# Altium schematic sheet ICWSHLP2_Adaptor_2x2_FanoutBuffer.SchDoc(ICWSHLP2_Adaptor_2x2_FanoutBuffer)
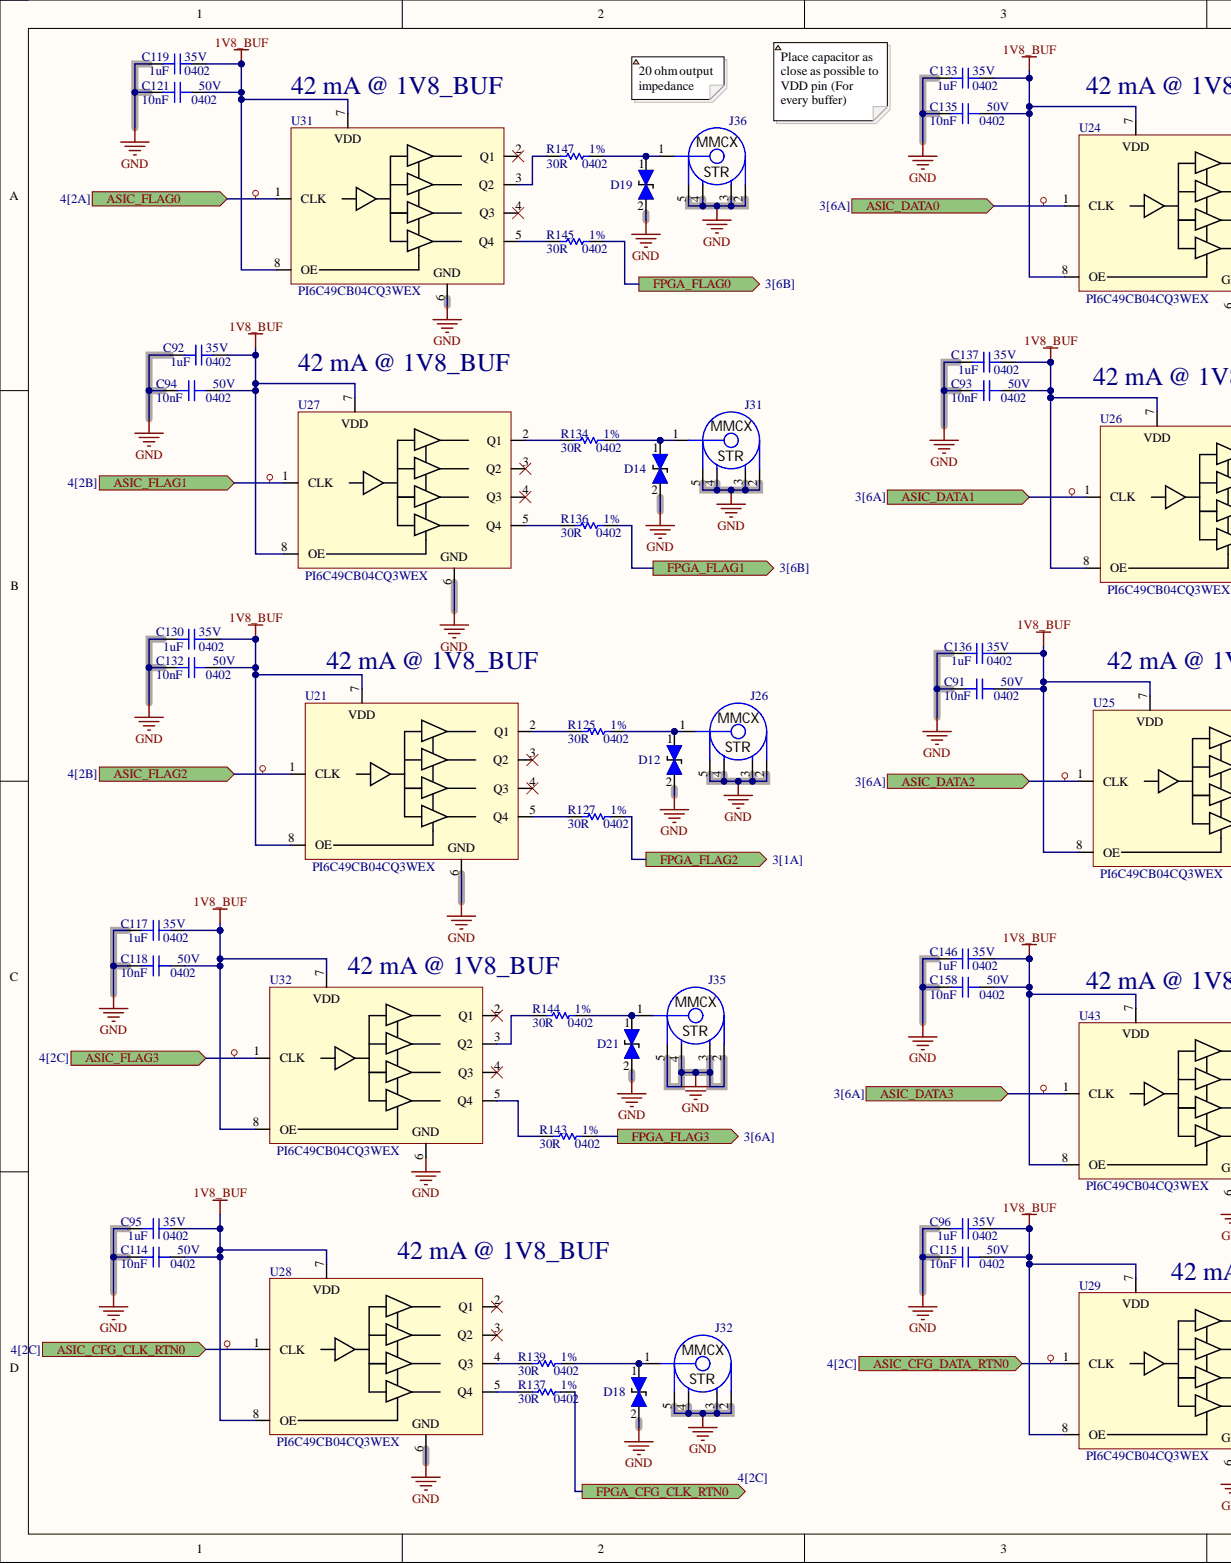
[diagram p0: ICWSHLP2_Adaptor_2x2_FanoutBuffer.SchDoc, left]
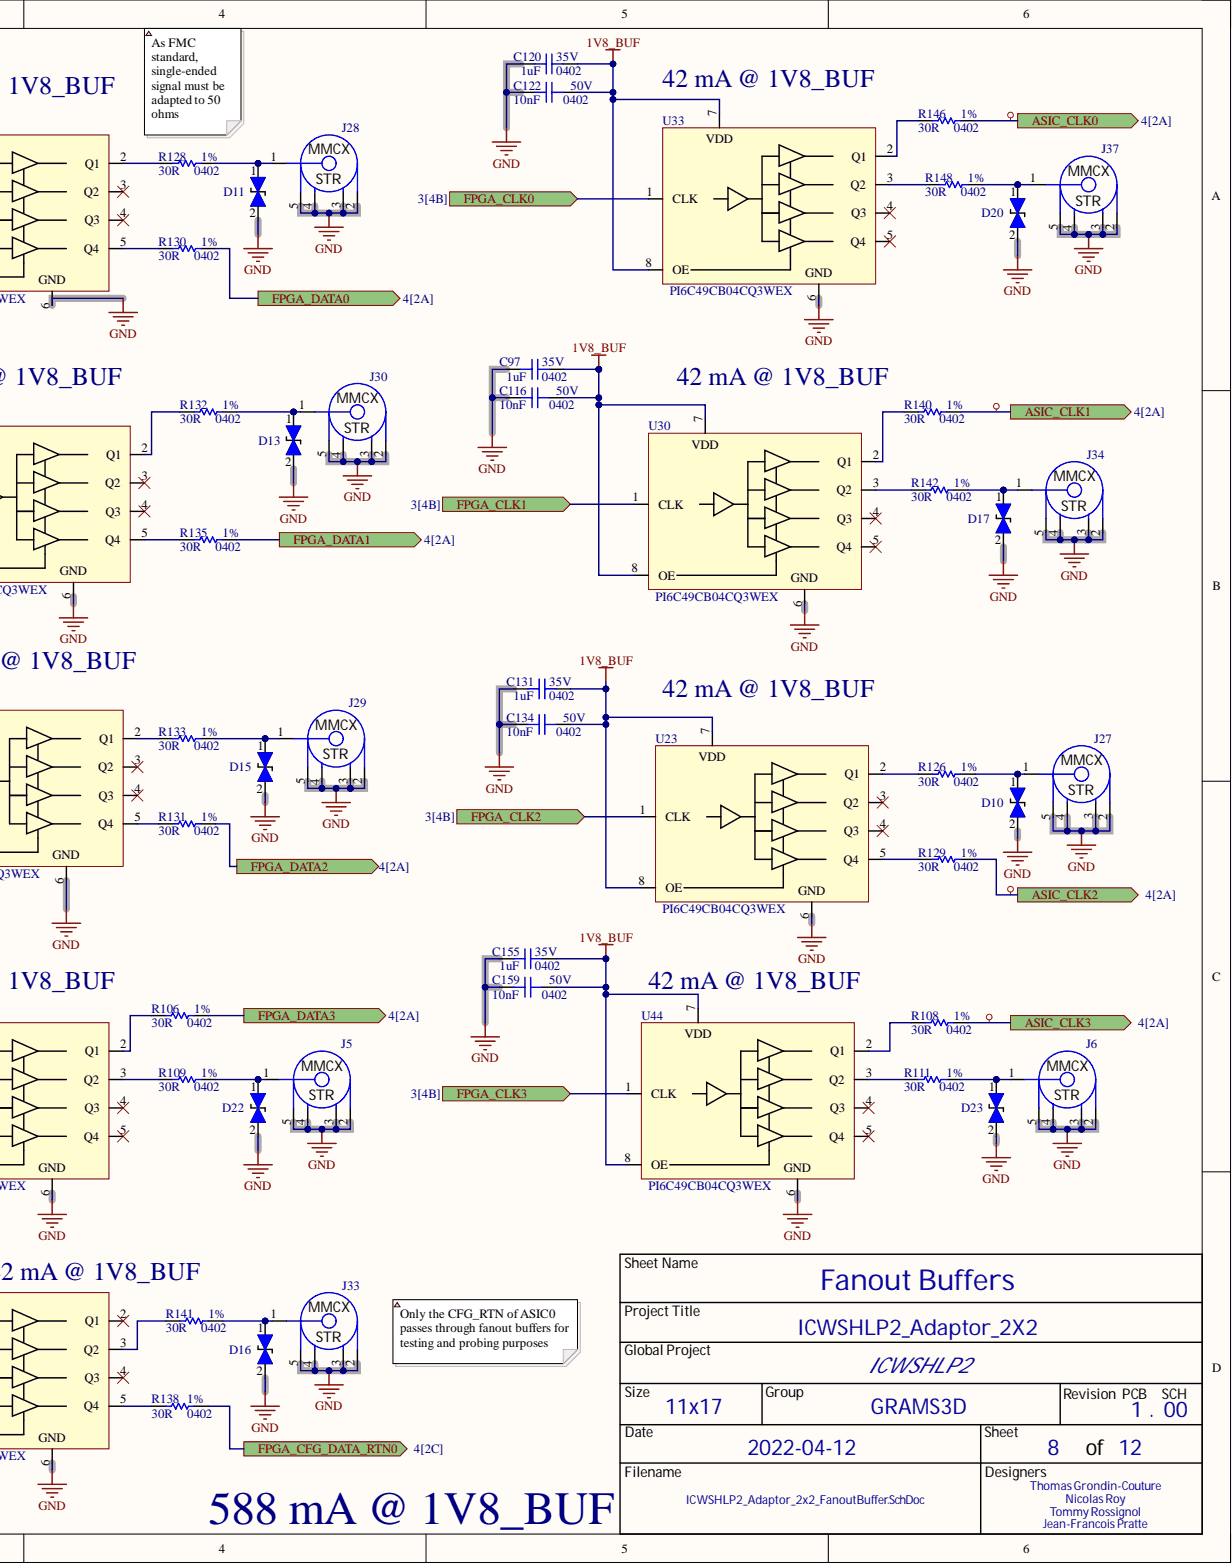
[diagram p1: ICWSHLP2_Adaptor_2x2_FanoutBuffer.SchDoc, right]

## Parts
C91  value 10nF  Package: 0402  Voltage Rating: 50V  [CAP_CER_0402]  (hidden comment: GRM155R71H103KA88D)
C92  value 1uF  Package: 0402  Voltage Rating: 35V  [CAP_CER_0402]  (hidden comment: CL05A105KL5NRNC)
C93  value 10nF  Package: 0402  Voltage Rating: 50V  [CAP_CER_0402]  (hidden comment: GRM155R71H103KA88D)
C94  value 10nF  Package: 0402  Voltage Rating: 50V  [CAP_CER_0402]  (hidden comment: GRM155R71H103KA88D)
C95  value 1uF  Package: 0402  Voltage Rating: 35V  [CAP_CER_0402]  (hidden comment: CL05A105KL5NRNC)
C96  value 1uF  Package: 0402  Voltage Rating: 35V  [CAP_CER_0402]  (hidden comment: CL05A105KL5NRNC)
C97  value 1uF  Package: 0402  Voltage Rating: 35V  [CAP_CER_0402]  (hidden comment: CL05A105KL5NRNC)
C114  value 10nF  Package: 0402  Voltage Rating: 50V  [CAP_CER_0402]  (hidden comment: GRM155R71H103KA88D)
C115  value 10nF  Package: 0402  Voltage Rating: 50V  [CAP_CER_0402]  (hidden comment: GRM155R71H103KA88D)
C116  value 10nF  Package: 0402  Voltage Rating: 50V  [CAP_CER_0402]  (hidden comment: GRM155R71H103KA88D)
C117  value 1uF  Package: 0402  Voltage Rating: 35V  [CAP_CER_0402]  (hidden comment: CL05A105KL5NRNC)
C118  value 10nF  Package: 0402  Voltage Rating: 50V  [CAP_CER_0402]  (hidden comment: GRM155R71H103KA88D)
C119  value 1uF  Package: 0402  Voltage Rating: 35V  [CAP_CER_0402]  (hidden comment: CL05A105KL5NRNC)
C120  value 1uF  Package: 0402  Voltage Rating: 35V  [CAP_CER_0402]  (hidden comment: CL05A105KL5NRNC)
C121  value 10nF  Package: 0402  Voltage Rating: 50V  [CAP_CER_0402]  (hidden comment: GRM155R71H103KA88D)
C122  value 10nF  Package: 0402  Voltage Rating: 50V  [CAP_CER_0402]  (hidden comment: GRM155R71H103KA88D)
C130  value 1uF  Package: 0402  Voltage Rating: 35V  [CAP_CER_0402]  (hidden comment: CL05A105KL5NRNC)
C131  value 1uF  Package: 0402  Voltage Rating: 35V  [CAP_CER_0402]  (hidden comment: CL05A105KL5NRNC)
C132  value 10nF  Package: 0402  Voltage Rating: 50V  [CAP_CER_0402]  (hidden comment: GRM155R71H103KA88D)
C133  value 1uF  Package: 0402  Voltage Rating: 35V  [CAP_CER_0402]  (hidden comment: CL05A105KL5NRNC)
C134  value 10nF  Package: 0402  Voltage Rating: 50V  [CAP_CER_0402]  (hidden comment: GRM155R71H103KA88D)
C135  value 10nF  Package: 0402  Voltage Rating: 50V  [CAP_CER_0402]  (hidden comment: GRM155R71H103KA88D)
C136  value 1uF  Package: 0402  Voltage Rating: 35V  [CAP_CER_0402]  (hidden comment: CL05A105KL5NRNC)
C137  value 1uF  Package: 0402  Voltage Rating: 35V  [CAP_CER_0402]  (hidden comment: CL05A105KL5NRNC)
C146  value 1uF  Package: 0402  Voltage Rating: 35V  [CAP_CER_0402]  (hidden comment: CL05A105KL5NRNC)
C155  value 1uF  Package: 0402  Voltage Rating: 35V  [CAP_CER_0402]  (hidden comment: CL05A105KL5NRNC)
C158  value 10nF  Package: 0402  Voltage Rating: 50V  [CAP_CER_0402]  (hidden comment: GRM155R71H103KA88D)
C159  value 10nF  Package: 0402  Voltage Rating: 50V  [CAP_CER_0402]  (hidden comment: GRM155R71H103KA88D)
D10  D5V0P1B2LP-7B  [TVS_DATA_D5V0P1B2LP-7B]  (value not drawn)
D11  D5V0P1B2LP-7B  [TVS_DATA_D5V0P1B2LP-7B]  (value not drawn)
D12  D5V0P1B2LP-7B  [TVS_DATA_D5V0P1B2LP-7B]  (value not drawn)
D13  D5V0P1B2LP-7B  [TVS_DATA_D5V0P1B2LP-7B]  (value not drawn)
D14  D5V0P1B2LP-7B  [TVS_DATA_D5V0P1B2LP-7B]  (value not drawn)
D15  D5V0P1B2LP-7B  [TVS_DATA_D5V0P1B2LP-7B]  (value not drawn)
D16  D5V0P1B2LP-7B  [TVS_DATA_D5V0P1B2LP-7B]  (value not drawn)
D17  D5V0P1B2LP-7B  [TVS_DATA_D5V0P1B2LP-7B]  (value not drawn)
D18  D5V0P1B2LP-7B  [TVS_DATA_D5V0P1B2LP-7B]  (value not drawn)
D19  D5V0P1B2LP-7B  [TVS_DATA_D5V0P1B2LP-7B]  (value not drawn)
D20  D5V0P1B2LP-7B  [TVS_DATA_D5V0P1B2LP-7B]  (value not drawn)
D21  D5V0P1B2LP-7B  [TVS_DATA_D5V0P1B2LP-7B]  (value not drawn)
D22  D5V0P1B2LP-7B  [TVS_DATA_D5V0P1B2LP-7B]  (value not drawn)
D23  D5V0P1B2LP-7B  [TVS_DATA_D5V0P1B2LP-7B]  (value not drawn)
J5  DATA3  [CON_MMCX_STR_CONMMCX001]
J6  CLK3  [CON_MMCX_STR_CONMMCX001]
J26  FLAG2  [CON_MMCX_STR_CONMMCX001]  (value not drawn)
J27  CLK2  [CON_MMCX_STR_CONMMCX001]  (value not drawn)
J28  DATA0  [CON_MMCX_STR_CONMMCX001]  (value not drawn)
J29  DATA2  [CON_MMCX_STR_CONMMCX001]  (value not drawn)
J30  DATA1  [CON_MMCX_STR_CONMMCX001]  (value not drawn)
J31  FLAG1  [CON_MMCX_STR_CONMMCX001]  (value not drawn)
J32  CLK_RTN0  [CON_MMCX_STR_CONMMCX001]  (value not drawn)
J33  DATA_RTN0  [CON_MMCX_STR_CONMMCX001]
J34  CLK1  [CON_MMCX_STR_CONMMCX001]  (value not drawn)
J35  FLAG3  [CON_MMCX_STR_CONMMCX001]  (value not drawn)
J36  FLAG0  [CON_MMCX_STR_CONMMCX001]  (value not drawn)
J37  CLK0  [CON_MMCX_STR_CONMMCX001]
R106  value 30R  Package: 0402  Tolerance: 1%  [RES_0402]  (hidden comment: RC0402FR-0730RL)
R108  value 30R  Package: 0402  Tolerance: 1%  [RES_0402]  (hidden comment: RC0402FR-0730RL)
R109  value 30R  Package: 0402  Tolerance: 1%  [RES_0402]  (hidden comment: RC0402FR-0730RL)
R111  value 30R  Package: 0402  Tolerance: 1%  [RES_0402]  (hidden comment: RC0402FR-0730RL)
R125  value 30R  Package: 0402  Tolerance: 1%  [RES_0402]  (hidden comment: RC0402FR-0730RL)
R126  value 30R  Package: 0402  Tolerance: 1%  [RES_0402]  (hidden comment: RC0402FR-0730RL)
R127  value 30R  Package: 0402  Tolerance: 1%  [RES_0402]  (hidden comment: RC0402FR-0730RL)
R128  value 30R  Package: 0402  Tolerance: 1%  [RES_0402]  (hidden comment: RC0402FR-0730RL)
R129  value 30R  Package: 0402  Tolerance: 1%  [RES_0402]  (hidden comment: RC0402FR-0730RL)
R130  value 30R  Package: 0402  Tolerance: 1%  [RES_0402]  (hidden comment: RC0402FR-0730RL)
R131  value 30R  Package: 0402  Tolerance: 1%  [RES_0402]  (hidden comment: RC0402FR-0730RL)
R132  value 30R  Package: 0402  Tolerance: 1%  [RES_0402]  (hidden comment: RC0402FR-0730RL)
R133  value 30R  Package: 0402  Tolerance: 1%  [RES_0402]  (hidden comment: RC0402FR-0730RL)
R134  value 30R  Package: 0402  Tolerance: 1%  [RES_0402]  (hidden comment: RC0402FR-0730RL)
R135  value 30R  Package: 0402  Tolerance: 1%  [RES_0402]  (hidden comment: RC0402FR-0730RL)
R136  value 30R  Package: 0402  Tolerance: 1%  [RES_0402]  (hidden comment: RC0402FR-0730RL)
R137  value 30R  Package: 0402  Tolerance: 1%  [RES_0402]  (hidden comment: RC0402FR-0730RL)
R138  value 30R  Package: 0402  Tolerance: 1%  [RES_0402]  (hidden comment: RC0402FR-0730RL)
R139  value 30R  Package: 0402  Tolerance: 1%  [RES_0402]  (hidden comment: RC0402FR-0730RL)
R140  value 30R  Package: 0402  Tolerance: 1%  [RES_0402]  (hidden comment: RC0402FR-0730RL)
R141  value 30R  Package: 0402  Tolerance: 1%  [RES_0402]  (hidden comment: RC0402FR-0730RL)
R142  value 30R  Package: 0402  Tolerance: 1%  [RES_0402]  (hidden comment: RC0402FR-0730RL)
R143  value 30R  Package: 0402  Tolerance: 1%  [RES_0402]  (hidden comment: RC0402FR-0730RL)
R144  value 30R  Package: 0402  Tolerance: 1%  [RES_0402]  (hidden comment: RC0402FR-0730RL)
R145  value 30R  Package: 0402  Tolerance: 1%  [RES_0402]  (hidden comment: RC0402FR-0730RL)
R146  value 30R  Package: 0402  Tolerance: 1%  [RES_0402]  (hidden comment: RC0402FR-0730RL)
R147  value 30R  Package: 0402  Tolerance: 1%  [RES_0402]  (hidden comment: RC0402FR-0730RL)
R148  value 30R  Package: 0402  Tolerance: 1%  [RES_0402]  (hidden comment: RC0402FR-0730RL)
U21  PI6C49CB04CQ3WEX  [TIM_FAN_PI6C49CB04CQ3WEX]  (value not drawn)
U23  PI6C49CB04CQ3WEX  [TIM_FAN_PI6C49CB04CQ3WEX]  (value not drawn)
U24  PI6C49CB04CQ3WEX  [TIM_FAN_PI6C49CB04CQ3WEX]  (value not drawn)
U25  PI6C49CB04CQ3WEX  [TIM_FAN_PI6C49CB04CQ3WEX]  (value not drawn)
U26  PI6C49CB04CQ3WEX  [TIM_FAN_PI6C49CB04CQ3WEX]  (value not drawn)
U27  PI6C49CB04CQ3WEX  [TIM_FAN_PI6C49CB04CQ3WEX]  (value not drawn)
U28  PI6C49CB04CQ3WEX  [TIM_FAN_PI6C49CB04CQ3WEX]  (value not drawn)
U29  PI6C49CB04CQ3WEX  [TIM_FAN_PI6C49CB04CQ3WEX]  (value not drawn)
U30  PI6C49CB04CQ3WEX  [TIM_FAN_PI6C49CB04CQ3WEX]  (value not drawn)
U31  PI6C49CB04CQ3WEX  [TIM_FAN_PI6C49CB04CQ3WEX]  (value not drawn)
U32  PI6C49CB04CQ3WEX  [TIM_FAN_PI6C49CB04CQ3WEX]  (value not drawn)
U33  PI6C49CB04CQ3WEX  [TIM_FAN_PI6C49CB04CQ3WEX]  (value not drawn)
U43  PI6C49CB04CQ3WEX  [TIM_FAN_PI6C49CB04CQ3WEX]  (value not drawn)
U44  PI6C49CB04CQ3WEX  [TIM_FAN_PI6C49CB04CQ3WEX]  (value not drawn)

## Ports
ASIC_CFG_CLK_RTN0, ASIC_CFG_DATA_RTN0, ASIC_CLK0, ASIC_CLK1, ASIC_CLK2, ASIC_CLK3, ASIC_DATA0, ASIC_DATA1, ASIC_DATA2, ASIC_DATA3, ASIC_FLAG0, ASIC_FLAG1, ASIC_FLAG2, ASIC_FLAG3, FPGA_CFG_CLK_RTN0, FPGA_CFG_DATA_RTN0, FPGA_CLK0, FPGA_CLK1, FPGA_CLK2, FPGA_CLK3, FPGA_DATA0, FPGA_DATA1, FPGA_DATA2, FPGA_DATA3, FPGA_FLAG0, FPGA_FLAG1, FPGA_FLAG2, FPGA_FLAG3

## Nets
1V8_BUF: C91.1 C92.1 C93.1 C94.1 C95.1 C96.1 C97.1 C114.1 C115.1 C116.1 C117.1 C118.1 C119.1 C120.1 C121.1 C122.1 C130.1 C131.1 C132.1 C133.1 C134.1 C135.1 C136.1 C137.1 C146.1 C155.1 C158.1 C159.1 U21.7 U21.8(OE) U23.7 U23.8(OE) U24.7 U24.8(OE) U25.7 U25.8(OE) U26.7 U26.8(OE) U27.7 U27.8(OE) U28.7 U28.8(OE) U29.7 U29.8(OE) U30.7 U30.8(OE) U31.7 U31.8(OE) U32.7 U32.8(OE) U33.7 U33.8(OE) U43.7 U43.8(OE) U44.7 U44.8(OE)
GND: C91.2 C92.2 C93.2 C94.2 C95.2 C96.2 C97.2 C114.2 C115.2 C116.2 C117.2 C118.2 C119.2 C120.2 C121.2 C122.2 C130.2 C131.2 C132.2 C133.2 C134.2 C135.2 C136.2 C137.2 C146.2 C155.2 C158.2 C159.2 D10.2 D11.2 D12.2 D13.2 D14.2 D15.2 D16.2 D17.2 D18.2 D19.2 D20.2 D21.2 D22.2 D23.2 J5.2 J5.3 J5.4 J5.5 J6.2 J6.3 J6.4 J6.5 J26.2 J26.3 J26.4 J26.5 J27.2 J27.3 J27.4 J27.5 J28.2 J28.3 J28.4 J28.5 J29.2 J29.3 J29.4 J29.5 J30.2 J30.3 J30.4 J30.5 J31.2 J31.3 J31.4 J31.5 J32.2 J32.3 J32.4 J32.5 J33.2 J33.3 J33.4 J33.5 J34.2 J34.3 J34.4 J34.5 J35.2 J35.3 J35.4 J35.5 J36.2 J36.3 J36.4 J36.5 J37.2 J37.3 J37.4 J37.5 U21.6 U23.6 U24.6 U25.6 U26.6 U27.6 U28.6 U29.6 U30.6 U31.6 U32.6 U33.6 U43.6 U44.6
ASIC_CFG_CLK_RTN0: U28.1(CLK) ; port ASIC_CFG_CLK_RTN0
ASIC_CFG_DATA_RTN0: U29.1(CLK) ; port ASIC_CFG_DATA_RTN0
ASIC_CLK0: R146.1 ; port ASIC_CLK0
ASIC_CLK1: R140.1 ; port ASIC_CLK1
ASIC_CLK2: R129.1 ; port ASIC_CLK2
ASIC_CLK3: R108.1 ; port ASIC_CLK3
ASIC_DATA0: U24.1(CLK) ; port ASIC_DATA0
ASIC_DATA1: U26.1(CLK) ; port ASIC_DATA1
ASIC_DATA2: U25.1(CLK) ; port ASIC_DATA2
ASIC_DATA3: U43.1(CLK) ; port ASIC_DATA3
ASIC_FLAG0: U31.1(CLK) ; port ASIC_FLAG0
ASIC_FLAG1: U27.1(CLK) ; port ASIC_FLAG1
ASIC_FLAG2: U21.1(CLK) ; port ASIC_FLAG2
ASIC_FLAG3: U32.1(CLK) ; port ASIC_FLAG3
FPGA_CFG_CLK_RTN0: R137.1 ; port FPGA_CFG_CLK_RTN0
FPGA_CFG_DATA_RTN0: R138.1 ; port FPGA_CFG_DATA_RTN0
FPGA_CLK0: U33.1(CLK) ; port FPGA_CLK0
FPGA_CLK1: U30.1(CLK) ; port FPGA_CLK1
FPGA_CLK2: U23.1(CLK) ; port FPGA_CLK2
FPGA_CLK3: U44.1(CLK) ; port FPGA_CLK3
FPGA_DATA0: R130.1 ; port FPGA_DATA0
FPGA_DATA1: R135.1 ; port FPGA_DATA1
FPGA_DATA2: R131.1 ; port FPGA_DATA2
FPGA_DATA3: R106.1 ; port FPGA_DATA3
FPGA_FLAG0: R145.1 ; port FPGA_FLAG0
FPGA_FLAG1: R136.1 ; port FPGA_FLAG1
FPGA_FLAG2: R127.1 ; port FPGA_FLAG2
FPGA_FLAG3: R143.1 ; port FPGA_FLAG3
NetD10_1: D10.1 J27.1 R126.1
NetD11_1: D11.1 J28.1 R128.1
NetD12_1: D12.1 J26.1 R125.1
NetD13_1: D13.1 J30.1 R132.1
NetD14_1: D14.1 J31.1 R134.1
NetD15_1: D15.1 J29.1 R133.1
NetD16_1: D16.1 J33.1 R141.1
NetD17_1: D17.1 J34.1 R142.1
NetD18_1: D18.1 J32.1 R139.1
NetD19_1: D19.1 J36.1 R147.1
NetD20_1: D20.1 J37.1 R148.1
NetD21_1: D21.1 J35.1 R144.1
NetD22_1: D22.1 J5.1 R109.1
NetD23_1: D23.1 J6.1 R111.1
NetR106_2: R106.2 U43.2(Q1)
NetR108_2: R108.2 U44.2(Q1)
NetR109_2: R109.2 U43.3(Q2)
NetR111_2: R111.2 U44.3(Q2)
NetR125_2: R125.2 U21.2(Q1)
NetR126_2: R126.2 U23.2(Q1)
NetR127_2: R127.2 U21.5(Q4)
NetR128_2: R128.2 U24.2(Q1)
NetR129_2: R129.2 U23.5(Q4)
NetR130_2: R130.2 U24.5(Q4)
NetR131_2: R131.2 U25.5(Q4)
NetR132_2: R132.2 U26.2(Q1)
NetR133_2: R133.2 U25.2(Q1)
NetR134_2: R134.2 U27.2(Q1)
NetR135_2: R135.2 U26.5(Q4)
NetR136_2: R136.2 U27.5(Q4)
NetR137_2: R137.2 U28.5(Q4)
NetR138_2: R138.2 U29.5(Q4)
NetR139_2: R139.2 U28.4(Q3)
NetR140_2: R140.2 U30.2(Q1)
NetR141_2: R141.2 U29.3(Q2)
NetR142_2: R142.2 U30.3(Q2)
NetR143_2: R143.2 U32.5(Q4)
NetR144_2: R144.2 U32.3(Q2)
NetR145_2: R145.2 U31.5(Q4)
NetR146_2: R146.2 U33.2(Q1)
NetR147_2: R147.2 U31.3(Q2)
NetR148_2: R148.2 U33.3(Q2)

Not connected: U21 3(Q2) 4(Q3); U23 3(Q2) 4(Q3); U24 3(Q2) 4(Q3); U25 3(Q2) 4(Q3); U26 3(Q2) 4(Q3); U27 3(Q2) 4(Q3); U28 2(Q1) 3(Q2); U29 2(Q1) 4(Q3); U30 4(Q3) 5(Q4); U31 2(Q1) 4(Q3); U32 2(Q1) 4(Q3); U33 4(Q3) 5(Q4); U43 4(Q3) 5(Q4); U44 4(Q3) 5(Q4)

## Library data of the parts
C91 C93 C94 C114-C116 C118 C121 C122 C132 C134 C135 C158 C159 = CAP_CER_GRM155R71H103KA88D: CAP - CER 10nF 10% 50V X7R - 0402; Manufacturer #1: Murata Electronics North America; Cryo: UNKNOWN; GRAMS Lib Spec: 2.8; Polarized: No; Assembly Type: SMD; Component Kind: Standard; HelpURL: https://search.murata.co.jp/Ceramy/image/img/A01X/G101/ENG/GRM155R71H103KA88-01.pdf; Library Name: GRAMS_MASTER_LIB.IntLib; Magnetic Material: Unknown; Part Number #1: GRM155R71H103KA88D; Supplier 1: Digi-Key; Supplier Part Number 1: 490-4516-1-ND; Temperature: -55°C ~ 125°C; Tolerance: 10%; CreatedBy/Date: C.Paulin - 19/06/2019; VerifiedBy: Nicolas V. (2019-07-04)
C92 C95-C97 C117 C119 C120 C130 C131 C133 C136 C137 C146 C155 = CAP_CER_CL05A105KL5NRNC: CAP - CER 1uF 10% 35V X5R - 0402; Manufacturer #1: Samsung Electro-Mechanics; Cryo: UNKNOWN; GRAMS Lib Spec: 2.8; Polarized: NO; Assembly Type: SMD; Component Kind: Standard; HelpURL: http://www.samsungsem.com/kr/support/product-search/mlcc/__icsFiles/afieldfile/2018/06/19/CL05A105KL5NRNC.pdf; Library Name: GRAMS_MASTER_LIB.IntLib; Magnetic Material: Unknown; Part Number #1: CL05A105KL5NRNC; Supplier 1: Digi-Key; Supplier Part Number 1: 1276-6796-1-ND; Temperature: -55°C ~ 85°C; Tolerance: 10%; CreatedBy/Date: Nicolas Roy 2018-10-03; VerifiedBy: Caroline Paulin - 18 janv 2019
D10-D23 = TVS_DATA_D5V0P1B2LP-7B: TVS - TVS DIODE 5.5V 13V - 0402; Manufacturer #1: Diodes Incorporated; Cryo: UNKNOWN; Current Rating: 4Apeak; GRAMS Lib Spec: 2.8; Polarized: Yes; Power Max: 250mW; Assembly Type: SMD; Component Kind: Standard; HelpURL: https://www.diodes.com/assets/Datasheets/D5V0P1B2LP.pdf; Library Name: GRAMS_MASTER_LIB.IntLib; Magnetic Material: Unknown; Package: 0402; Part Number #1: D5V0P1B2LP-7B; Supplier 1: Digi-Key; Supplier Part Number 1: D5V0P1B2LP-7BDICT-ND; Temperature: -65°C ~ 150°C (TJ); Voltage Rating: 5.5V; CreatedBy/Date: Keven Deslandes - 2018/10/19; VerifiedBy: Caroline Paulin -28 janvier 2018
J5 J6 J26-J37 = CON_MMCX_STR_CONMMCX001: CON - MMCX female socket 50Ohm - TH -  Straight; Manufacturer #1: Linx Technologies Inc.; Cryo: Unknown; GRAMS Lib Spec: 2.9; Polarized: No; Value: 50 Ohm, 6 GHz; Voltage rating: 170V; Assembly Type: TH; Component Kind: Standard; HelpURL: https://linxtechnologies.com/wp/wp-content/uploads/conmmcx001.pdf; Library Name: GRAMS_MASTER_LIB.IntLib; Magnetic Material: Unknown; Note: Straight; Package: TH; Part Number #1: CONMMCX001; Supplier 1: Digi-Key; Supplier Part Number 1: CONMMCX001-ND; Temperature: -65°C ~ 165°C; CreatedBy/Date: Nicolas St-Jean 2020-07-27
R106 R108 R109 R111 R125-R148 = RES_RC0402FR-0730RL: RES - 30R 1% 1/16W - 0402; Manufacturer #1: Yageo; Cryo: UNKNOWN; GRAMS Lib Spec: 2.8; Polarized: No; Voltage Rating: 50V; Assembly Type: SMD; Component Kind: Standard; HelpURL: http://www.yageo.com/documents/recent/PYu-RC_Group_51_RoHS_L_10.pdf; Library Name: GRAMS_MASTER_LIB.IntLib; Magnetic Material: Unknown; Part Number #1: RC0402FR-0730RL; Power Max: 1/16W; Supplier 1: Digi-Key; Supplier Part Number 1: 311-30LRCT-ND; Temperature: -55°C ~ 155°C; CreatedBy/Date: Keven Deslandes 2018-09-27; VerifiedBy: Caroline Paulin - 8 janv 2019
U21 U23-U33 U43 U44 = TIM_FAN_PI6C49CB04CQ3WEX: TIM - LVCMOS Clock Buffer, 4CH, 160MHz, -  8-SOIC; Manufacturer #1: Diodes Incorporated; Cryo: UNKOWN; GRAMS Lib Spec: 2.9; Polarized: Yes; Voltage Rating: 1.425V ~ 3.6V; Assembly Type: SMD; Component Kind: Standard; Current Rating: -; HelpURL: https://www.diodes.com/assets/Datasheets/PI6C49CB04CQ.pdf; Library Name: GRAMS_MASTER_LIB.IntLib; Magnetic Material: UNKOWN; Manufacturer #2: -; Package: 8-SOIC; Part Number #1: PI6C49CB04CQ3WEX; Part Number #2: -; Power Max: -; Supplier 1: Digi-key; Supplier Part Number 1: 31-PI6C49CB04CQ3WEXCT-ND; Temperature: -40°C ~ 85°C (TA); Tolerance: -; Value: -; CreatedBy/Date: Thomas Grondin-Couture / 2020-09-16; VerifiedBy: C.Paulin - 28 oct 2020
